# Altium schematic sheet Sheet1_connection.SchDoc(Sheet1_connection)
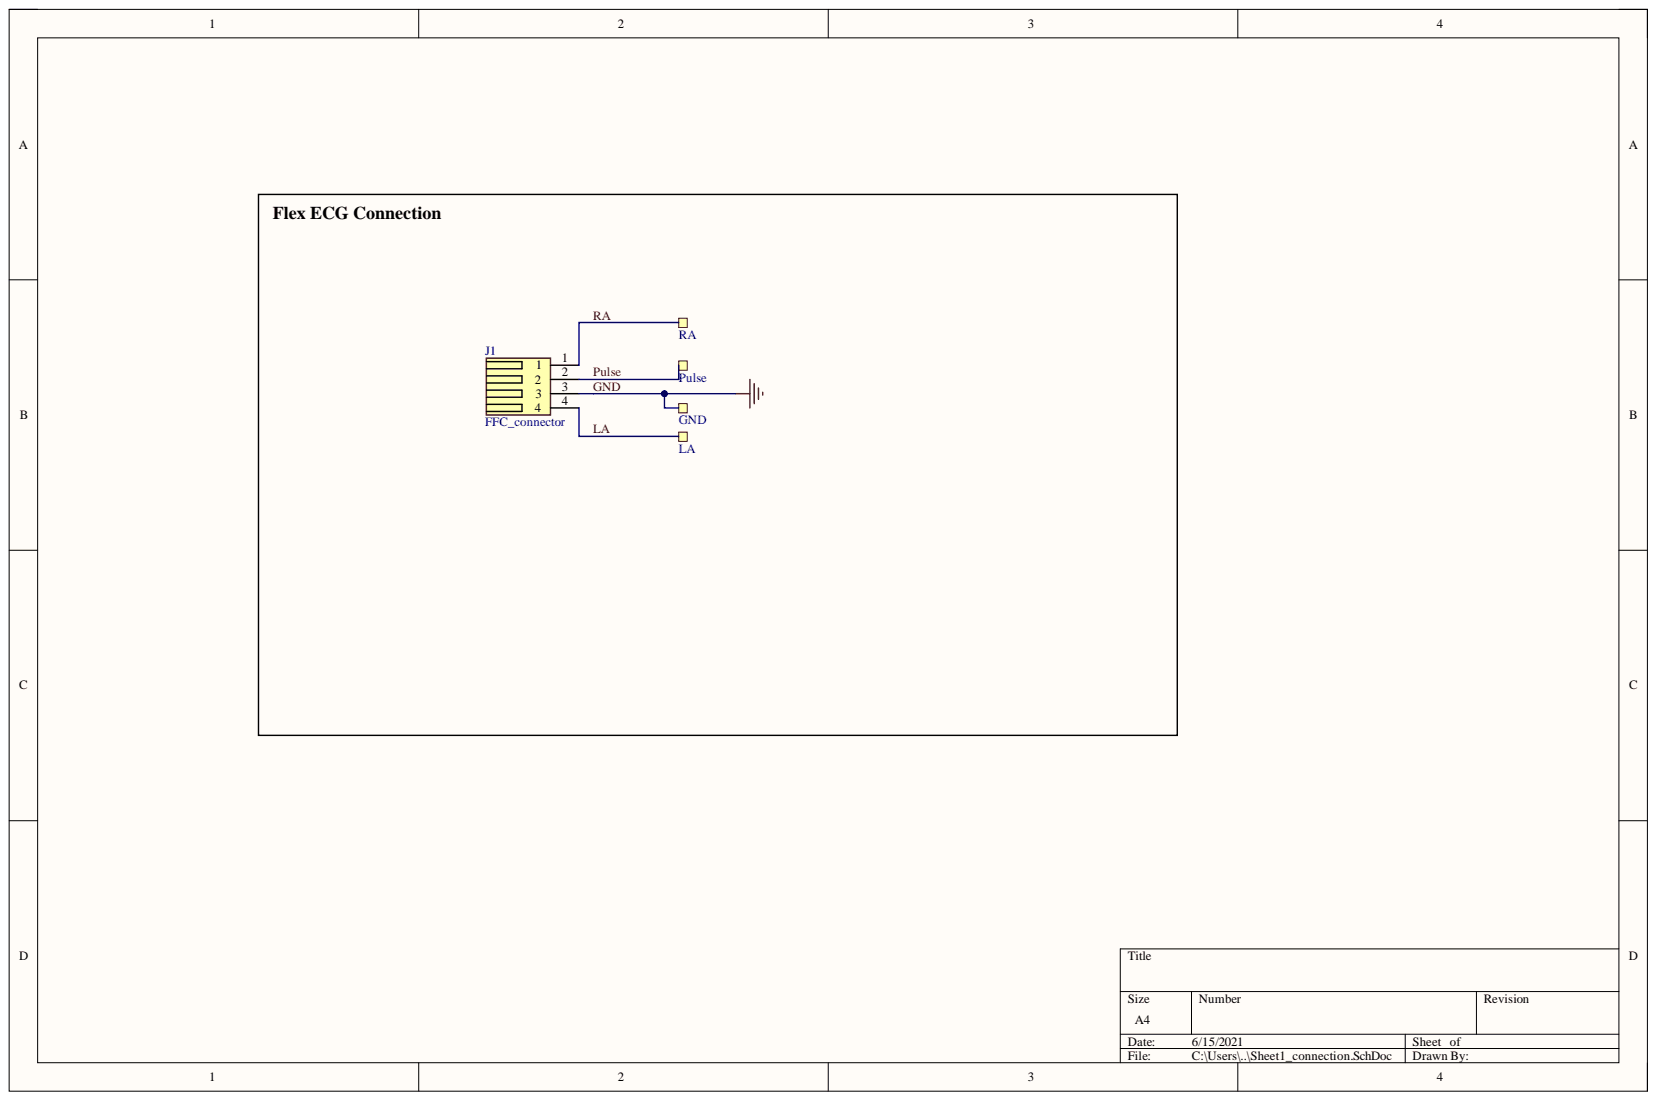
[diagram p0: Sheet1_connection.SchDoc]

## Parts
GND  (no value)  [ECG_Flex_Connector]  (no BOM)
J1  FFC_connector  [FPC_0.5mm_Connector_4pin]
LA  (no value)  [ECG_Flex_Connector]  (no BOM)
Pulse  (no value)  [ECG_Flex_Connector]  (no BOM)
RA  (no value)  [ECG_Flex_Connector]  (no BOM)

## Nets
GND: GND.1 J1.3
LA: J1.4 LA.1
Pulse: J1.2 Pulse.1
RA: J1.1 RA.1

## Library data of the parts
GND LA Pulse RA = TP_TH_Flex: Testpad for ECG flex connector; Library Name: PatchKeeper.Schlib
J1 = FPC_connector_4pin: FFC/FPC Connector, 4 pin plug, pitch 0.5mm, 0.3mm strip; Manufacturer_Name: TE Connectivity; Manufacturer_Part_Number: 2328702-6; Component Kind: Standard; Datasheet Link: https://componentsearchengine.com//2328702-6.pdf; Height: 1.1mm; Mouser2 Part Number: 571-2328702-6; Mouser2 Price/Stock: https://www.mouser.com/Search/Refine.aspx?Keyword=571-2328702-6; Library Name: PatchKeeper.Schlib
